# Altium schematic sheet 173SchemV0.1.SchDoc(173SchemV0.1)
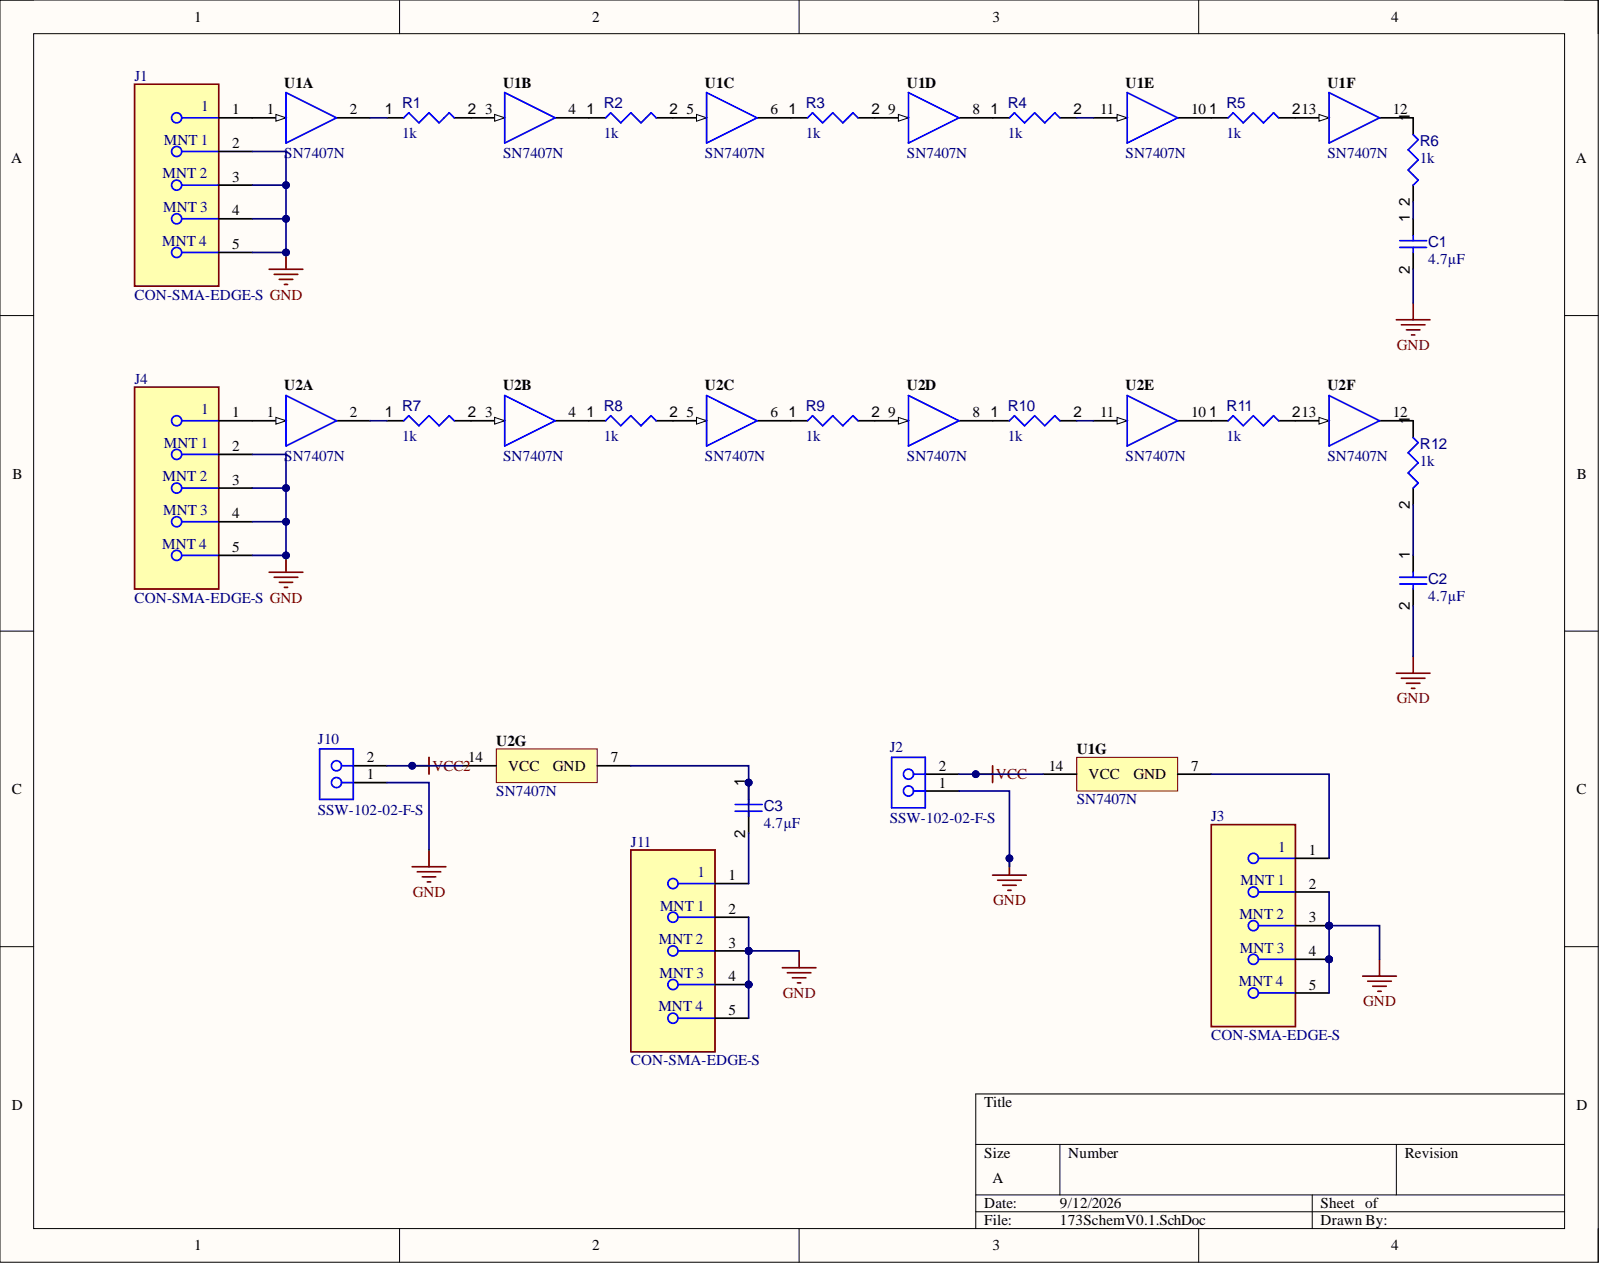
[diagram p0: 173SchemV0.1.SchDoc]

## Parts
C1  value 4.7µF  (hidden comment: Capacitor 4.7µF +/-40% 25V 0805)
C2  value 4.7µF  (hidden comment: Capacitor 4.7µF +/-40% 25V 0805)
C3  value 4.7µF  (hidden comment: Capacitor 4.7µF +/-40% 25V 0805)
J1  CON-SMA-EDGE-S
J2  SSW-102-02-F-S
J3  CON-SMA-EDGE-S
J4  CON-SMA-EDGE-S
J10  SSW-102-02-F-S
J11  CON-SMA-EDGE-S
R1  value 1k  (hidden comment: 1KR5J)
R2  value 1k  (hidden comment: 1KR5J)
R3  value 1k  (hidden comment: 1KR5J)
R4  value 1k  (hidden comment: 1KR5J)
R5  value 1k  (hidden comment: 1KR5J)
R6  value 1k  (hidden comment: 1KR5J)
R7  value 1k  (hidden comment: 1KR5J)
R8  value 1k  (hidden comment: 1KR5J)
R9  value 1k  (hidden comment: 1KR5J)
R10  value 1k  (hidden comment: 1KR5J)
R11  value 1k  (hidden comment: 1KR5J)
R12  value 1k  (hidden comment: 1KR5J)
U1  SN7407N  (parts A,B,C,D,E,F,G)
U2  SN7407N  (parts A,B,C,D,E,F,G)

## Nets
GND: C1.2 C2.2 J1.2 J1.3 J1.4 J1.5 J2.1 J3.2 J3.3 J3.4 J3.5 J4.2 J4.3 J4.4 J4.5 J10.1 J11.2 J11.3 J11.4 J11.5
VCC: J2.2 U1.14(VCC)
VCC2: J10.2 U2.14(VCC)
NetC1_1: C1.1 R6.2
NetC2_1: C2.1 R12.2
NetC3_1: C3.1 U2.7(GND)
NetC3_2: C3.2 J11.1
NetJ1_1: J1.1 U1.1
NetJ3_1: J3.1 U1.7(GND)
NetJ4_1: J4.1 U2.1
NetR1_1: R1.1 U1.2
NetR1_2: R1.2 U1.3
NetR2_1: R2.1 U1.4
NetR2_2: R2.2 U1.5
NetR3_1: R3.1 U1.6
NetR3_2: R3.2 U1.9
NetR4_1: R4.1 U1.8
NetR4_2: R4.2 U1.11
NetR5_1: R5.1 U1.10
NetR5_2: R5.2 U1.13
NetR6_1: R6.1 U1.12
NetR7_1: R7.1 U2.2
NetR7_2: R7.2 U2.3
NetR8_1: R8.1 U2.4
NetR8_2: R8.2 U2.5
NetR9_1: R9.1 U2.6
NetR9_2: R9.2 U2.9
NetR10_1: R10.1 U2.8
NetR10_2: R10.2 U2.11
NetR11_1: R11.1 U2.10
NetR11_2: R11.2 U2.13
NetR12_1: R12.1 U2.12

## Library data of the parts
C1-C3 = CMP-001-00080-4: Chip Capacitor, 4.7µF +/-40%, 25V, 0805, Thickness 1.45 mm; Tolerance: 20%; Case/Package: 0805; Component Kind: Standard; Max Operating Temperature: 85°C; Min Operating Temperature: -55°C; Mounting Technology: SMT; Pins: 2; Voltage Rating: 25V; Library Name: Francesco Menegoli
J1 J3 J4 J11 = CMP-204357-000001-1: CONN SMA JACK STR EDGE MNT; Manufacturer: RF Solutions Ltd.; Conn Orientation: Right Angle; Frequency: 18GHz; Impedance: 50Ω; Is Female: yes; Number Of Contacts: 1; Shielding: true; Automotive: No; Category: Conn; Component Kind: Standard; ComponentLink2Description: Datasheet; ComponentLink2URL: https://www.rfsolutions.co.uk/downloads/1498648656DS-CON-EDGE-SMA-4.pdf; Contact Material: Gold; Device Class L1: Connectors; Device Class L2: RF / Coaxial Connectors; Device Class L3: unset; Digikey Description: CONN SMA JACK STR EDGE MNT; Footprint Url: https://forum.upverter.com/uploads/default/original/3X/b/e/be75b907af57498775929d6d9099689c472e0307.pdf; Height: 6.35mm; Lead Free: Yes; Library Name: Altium Content Vault; Manufacturer Part Number: CON-SMA-EDGE-S; Octopart: https://octopart.com/con-sma-edge-s-rf+solutions-70967450; Package: CONN_JACK; RoHS: TRUE
J2 J10 = CMP-1023-00322-1: SQ Post Socket, Through-hole, Vertical, -55 to 125 degC, 2.54 mm Pitch, 2-Pin, Female, RoHS; Manufacturer: Samtec; Pitch: 2.54 mm; Component Kind: Standard; ComponentLink1Description: Manufacturer URL; ComponentLink1URL: http://www.samtec.com; ComponentLink2Description: Datasheet; ComponentLink2URL: http://www.samtec.com/documents/webfiles/pdf/SSW_TH.PDF; ComponentLink3Description: Package Specification; ComponentLink3URL: http://www.samtec.com/documents/webfiles/cpdf/SSW-1XX-XX-XXX-X-XX-XXX-XX-MKT.pdf; DatasheetVersion: F-214; Library Name: Altium Content Vault; Mounting Technology: Through-hole; Orientation: Vertical; PackageDescription: Assembly, Socket Strip .016 X .031 Tail; PackageReference: SSW-102-02-X-S; PackageVersion: CA, 6/1987; PartNumber: SSW-102-02-F-S; RoHS: True; Temperature Range: -55 to 125 degC
R1-R12 = CMP-009-00118-3: Chip Resistor, 1 kOhms, +/-5%, 125 mW, -55 to 155 degC, 0805; Power: 125mW; Tolerance: 5%; Case/Package: 0805; Component Kind: Standard; Max Operating Temperature: 155°C; Min Operating Temperature: -55°C; Pins: 2; Library Name: Ali Azgomi
U1 U2 = CMP-0440-00927-3: Hex Buffers/Drivers With Open-Collector High-Voltage Outputs, N0014A, TUBE; Generic Part Number: SN7407; Manufacturer: Texas Instruments; Bits(#): 6; F @ Nom Voltage(Max)(Mhz): 75; ICC @ Nom Voltage(Max)(mA): 0.041; Input Type: TTL; Rating: Catalog; tpd @ Nom Voltage(Max)(ns): 30; VCC(Max)(V): 5.25; VCC(Min)(V): 4.75; Voltage(Nom)(V): 5; Code_JEDEC: MS-001; Component Kind: Standard; DatasheetVersion: SDLS032G; Library Name: Altium Content Vault; Operating Temperature Range(C): 0 to 70; Output Type: TTL; PackageReference: N0014A; PackageVersion: http://www.ti.com/lit/ml/mpdi002c/mpdi002c.pdf
